# Altium schematic sheet FrameSync.SchDoc(FrameSync)
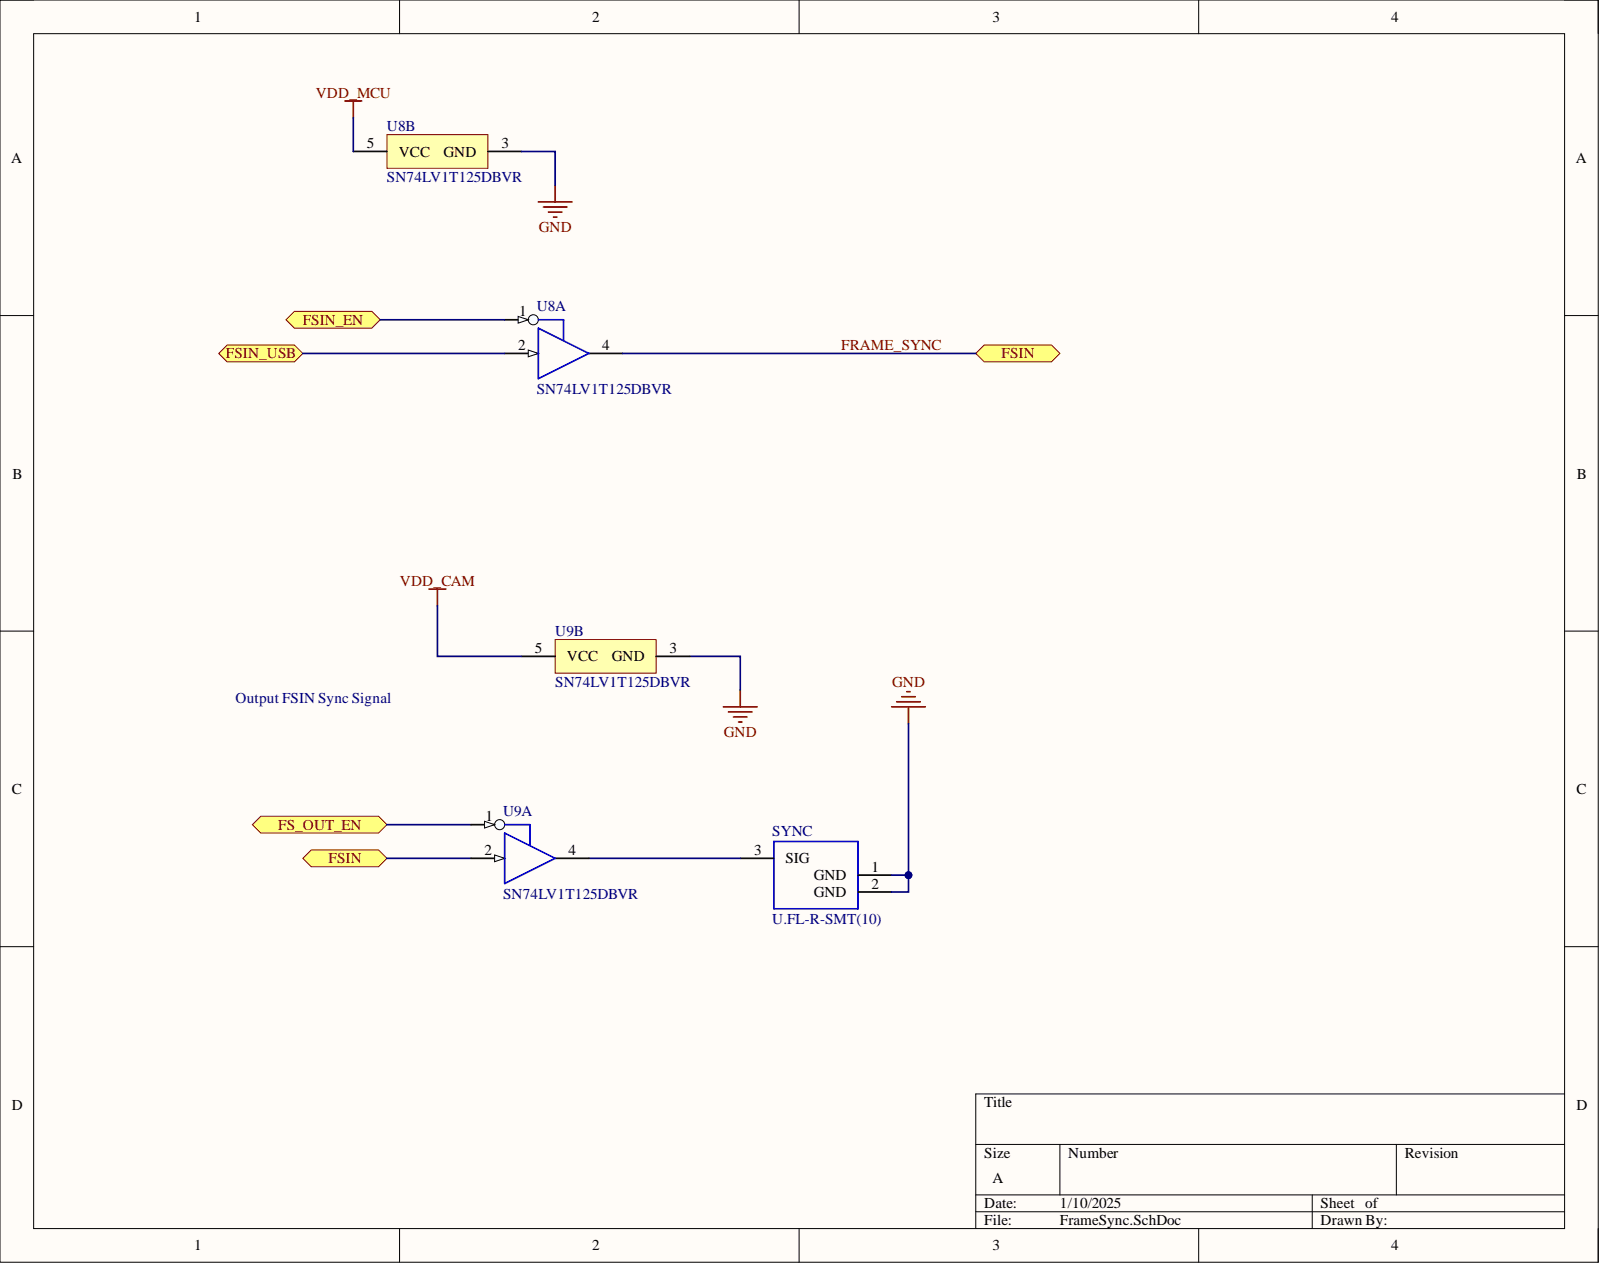
[diagram p0: FrameSync.SchDoc]

## Parts
SYNC  U.FL-R-SMT(10)
U8  SN74LV1T125DBVR  (parts A,B)
U9  SN74LV1T125DBVR  (parts A,B)

## Ports
FS_OUT_EN, FSIN, FSIN_EN, FSIN_USB

## Nets
GND: SYNC.1 SYNC.2 U8.3(GND) U9.3(GND)
VDD_CAM: U9.5(VCC)
VDD_MCU: U8.5(VCC)
FRAME_SYNC: U8.4 U9.2 ; port FSIN
FS_OUT_EN: U9.1 ; port FS_OUT_EN
FSIN_EN: U8.1 ; port FSIN_EN
FSIN_USB: U8.2 ; port FSIN_USB
NetSYNC_3: SYNC.3 U9.4

## Library data of the parts
SYNC = CMP-002-00035-2: Ultra Small Surface Mount Coaxial Connector, 50 Ohm, -40 to 90 degC, 3-Pin SMD, RoHS, Tape and Reel; Manufacturer: Hirose Electric; Connector Type: RF&Coax; Impedance: 50Ohm; Case/Package: U.FL-R-SMT; Component Kind: Standard; Contact Material: Brass; Contact Plating: Gold; Datasheet URL: https://www.hirose.com/product/en/download_file/key_name/U.FL/category/Catalog/doc_file_id/31697/?file_category_id=4&item_id=15&is_series=1; Datasheet Version: Aug-16; Fastening Type: Snap; Manufacturer URL: https://www.hirose.com; Max Operating Temperature: 90°C; Min Operating Temperature: -40°C; Mounting Technology: SM; Package Description: 3-Pin Surface Mount Device, Body 2.6 x 2.6 mm; Package Quantity: 2500; Package Reference: U.FL-R-SMT; Packaging: TapeandReel; Resistance: 50Ohm; RoHS Compliant: True; Library Name: Openwater
U8 U9 = CMP-0859-00122-3: Single Power Supply, Single BUFFER GATE w/ 3-State Output (active low enable), DBV0005A, LARGE T&R; Manufacturer: Texas Instruments; 3-State Output: Yes; Bits(#): 1; F @ Nom Voltage(Max)(Mhz): 50; ICC @ Nom Voltage(Max)(mA): 0.01; Input Type: TTL; Logic: True; Output Drive (IOL/IOH)(Max)(mA): 8/-8; Output Type: CMOS; Rating: Catalog; Schmitt Trigger: No; Special Features: 1.8V to 3.3V Up Translation,3.3V to 1.8V Down Translation,5V Input Tolerant; Sub-Family: Non-Inverting Buffer/Driver; Technology Family: LV1T; tpd @ Nom Voltage(Max)(ns): 14.5,9.5,8.0,6.5; VCC(Max)(V): 5.5; VCC(Min)(V): 1.6; Voltage(Nom)(V): 1.8,2.5,3.3,5.5; Component Kind: Standard; DatasheetVersion: SCLS745A; Generic Part Number: SN74LV1T125; Library Name: Altium Content Vault; Operating Temperature Range(C): -40 to 125; PackageReference: DBV0005A
